# Altium schematic sheet esquematico.SchDoc
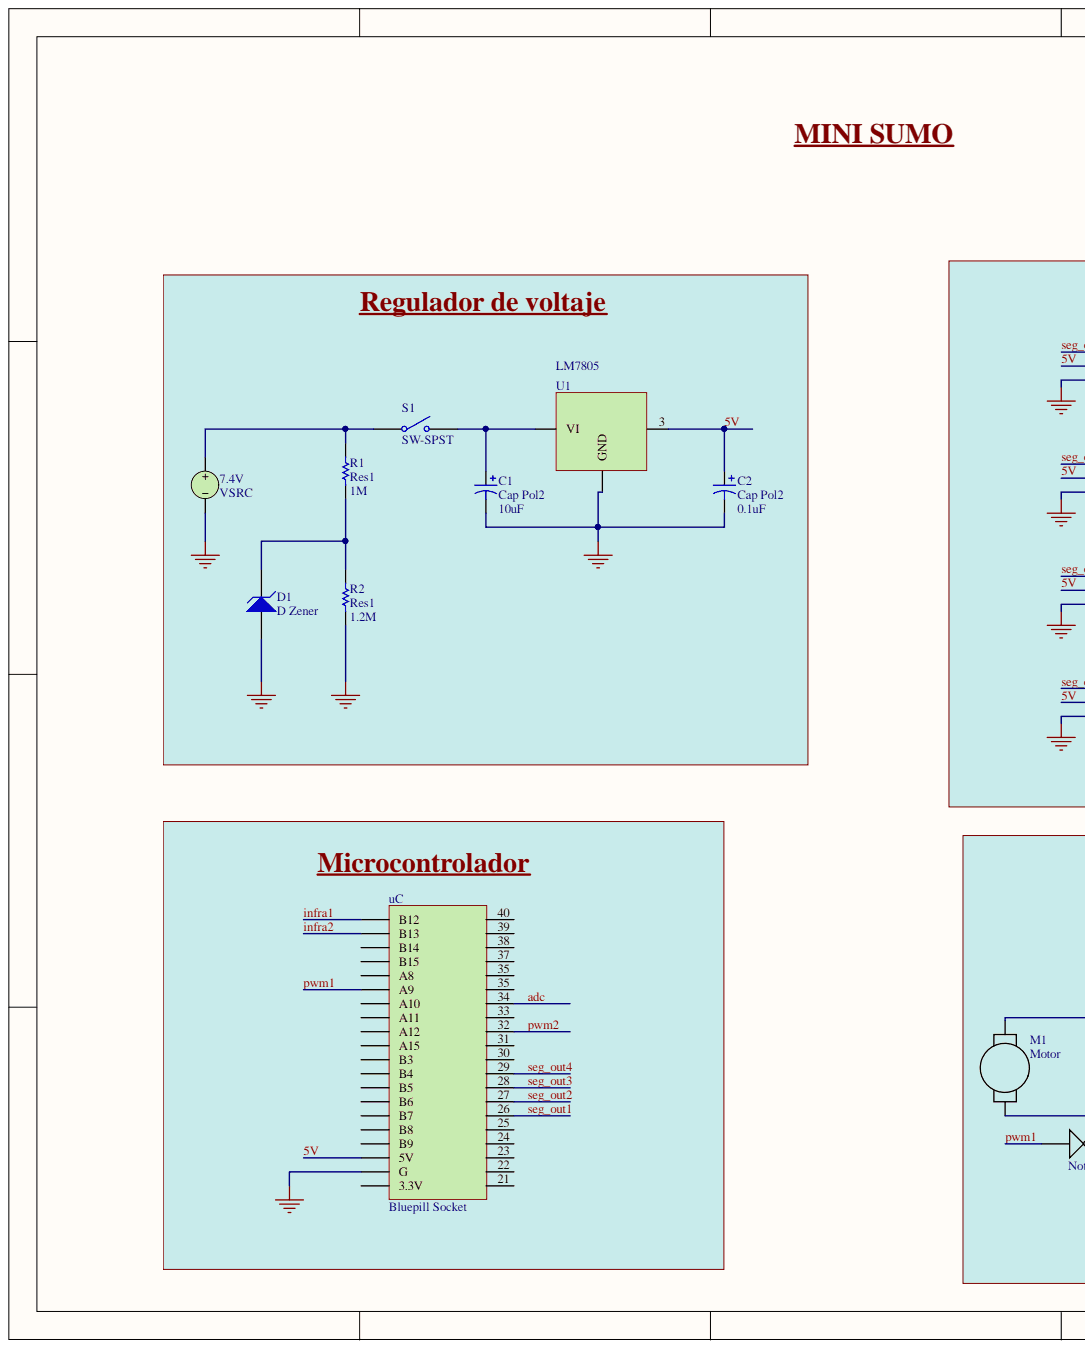
[diagram p0: esquematico.SchDoc, left]
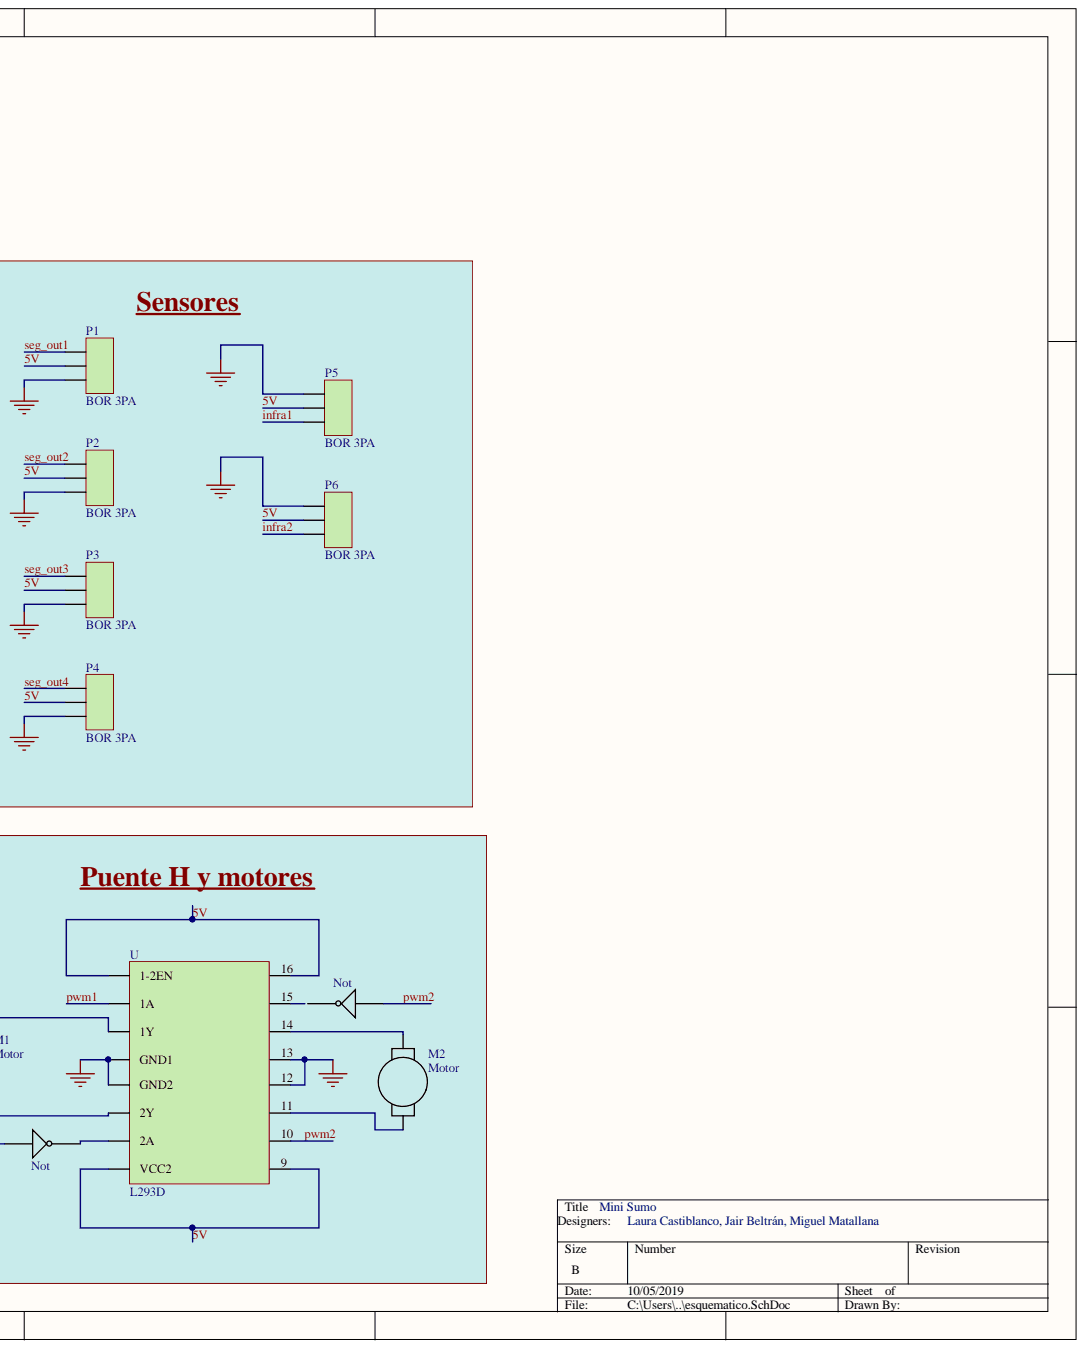
[diagram p1: esquematico.SchDoc, right]

## Parts
7.4V  VSRC
C1  Cap Pol2  value 10uF  [CAP RAD]
C2  Cap Pol2  value 0.1uF  [CAP RAD]
D1  D Zener  [DIODE-0.7]
M1  Motor
M2  Motor
P1  BOR 3PA  [BOR 1X3]
P2  BOR 3PA  [BOR 1X3]
P3  BOR 3PA  [BOR 1X3]
P4  BOR 3PA  [BOR 1X3]
P5  BOR 3PA  [BOR 1X3]
P6  BOR 3PA  [BOR 1X3]
R1  Res1  value 1M  [AXIAL-0.3]
R2  Res1  value 1.2M  [AXIAL-0.3]
S1  SW-SPST  [SPST-2]
U  L293D  [4N25]
U1  LM7805  [LM7805]
uC  Bluepill Socket  [BluePill Socket]
  Not  [1N4001]
  Not  [1N4001]

## Nets
GND: 7.4V.2 C1.2 C2.2 D1.1 P1.3 P2.3 P3.3 P4.3 P5.1 P6.1 R2.1 U.4(GND1) U.5(GND2) U.12 U.13 U1.2 uC.19(G)
Net_2: U.7(2A) .2
5V: C2.1 P1.2 P2.2 P3.2 P4.2 P5.2 P6.2 U.1(1-2EN) U.8(VCC2) U.9 U.16 U1.3 uC.18(5V)
adc: uC.34
infra1: P5.3 uC.1(B12)
infra2: P6.3 uC.2(B13)
pwm1: U.2(1A) uC.6(A9) .1
pwm2: U.10 uC.32 .1
seg_out1: P1.1 uC.26
seg_out2: P2.1 uC.27
seg_out3: P3.1 uC.28
seg_out4: P4.1 uC.29
Net7.4V_1: 7.4V.1 R1.2 S1.1
NetC1_1: C1.1 S1.2 U1.1
NetD1_2: D1.2 R1.1 R2.2
NetM1_1: M1.1 U.3(1Y)
NetM1_2: M1.2 U.6(2Y)
NetM2_1: M2.1 U.14
NetM2_2: M2.2 U.11

Not connected: U 15; uC 3(B14) 4(B15) 5(A8) 7(A10) 8(A11) 9(A12) 10(A15) 11(B3) 12(B4) 13(B5) 14(B6) 15(B7) 16(B8) 17(B9) 20(3.3V) 21 22 23 24 25 30 31 33 35 37 38 39 40

## Library data of the parts
7.4V = VSRC: Voltage Source; Note: PCB Footprint - Not required; Value: +5; Component Kind: Standard; LatestRevisionDate: 17-Jul-2002; LatestRevisionNote: Re-released for DXP Platform.; Library Name: Simulation Sources.IntLib; PackageReference: Not Applicable; Published: 8-Jun-2000; Simulation: VSRC; Publisher: Altium Limited
C1 C2 = Cap Pol2: Polarized Capacitor (Axial); Component Kind: Standard; LatestRevisionDate: 17-Jul-2002; LatestRevisionNote: Re-released for DXP Platform.; PackageReference: POLAR0.8; Published: 8-Jun-2000; Signal Integrity: Cap Pol; Simulation: CAP; Library Name: Biblioteca Esquematica Schlib1.SchLib; Publisher: Altium Limited
D1 = D Zener: Zener Diode; Component Kind: Standard; LatestRevisionDate: 17-Jul-2002; LatestRevisionNote: Re-released for DXP Platform.; Library Name: Miscellaneous Devices.IntLib; PackageDescription: Diode, Thru-Hole; 2 Leads; 0.7 in Pin Spacing; PackageReference: DIODE-0.7; Published: 8-Jun-2000; Signal Integrity: D Zener; Simulation: ZENER; Publisher: Altium Limited
R1 R2 = Res1: Resistor; Component Kind: Standard; LatestRevisionDate: 17-Jul-2002; LatestRevisionNote: Re-released for DXP Platform.; Library Name: Miscellaneous Devices.IntLib; PackageDescription: Axial Device, Thru-Hole; 2 Leads; 0.3 in Pin Spacing; PackageReference: AXIAL-0.3; Published: 8-Jun-2000; Signal Integrity: Res; Simulation: RESISTOR; Publisher: Altium Limited
S1 = SW-SPST: Single-Pole, Single-Throw Switch; Component Kind: Standard; LatestRevisionDate: 17-Jul-2002; LatestRevisionNote: Re-released for DXP Platform.; Library Name: Miscellaneous Devices.IntLib; PackageDescription: Switch; 2 Leads; PackageReference: SPST-2; Published: 24-Mar-1999; Publisher: Altium Limited
  = Not: 1 Amp General Purpose Rectifier; Code_JEDEC: DO-204-AL; Component Kind: Standard; ComponentLink1Description: Manufacturer Link; ComponentLink1URL: http://www.fairchildsemi.com/; ComponentLink2Description: Datasheet; ComponentLink2URL: http://www.fairchildsemi.com/ds/1N%2F1N4001.pdf; DatasheetVersion: 1998; LatestRevisionDate: 17-Jul-2002; LatestRevisionNote: Re-released for DXP Platform.; PackageReference: DO-41; PackageVersion: Aug-1999; Published: 8-Jun-2000; Signal Integrity: 1N4001; Simulation: 1N4001; Library Name: Biblioteca Esquematica Schlib1.SchLib; Publisher: Altium Limited
M1 M2 = Motor: Component Kind: Standard; Library Name: Biblioteca Esquematica Schlib1.SchLib
P1-P6 = BOR 3PA: Component Kind: Standard; Library Name: Biblioteca Esquematica Schlib1.SchLib
U = L293D: Component Kind: Standard; Library Name: Biblioteca Esquematica Schlib1.SchLib
U1 = LM7805: Component Kind: Standard; Library Name: Biblioteca Esquematica Schlib1.SchLib
uC = Bluepill Socket: Component Kind: Standard; LatestRevisionDate: 17-Jul-2002; LatestRevisionNote: Re-released for DXP Platform.; Library Name: Biblioteca Esquematica Schlib1.SchLib; Publisher: Altium Limited
